# Altium schematic sheet MuxBoard.SchDoc(MuxBoard)
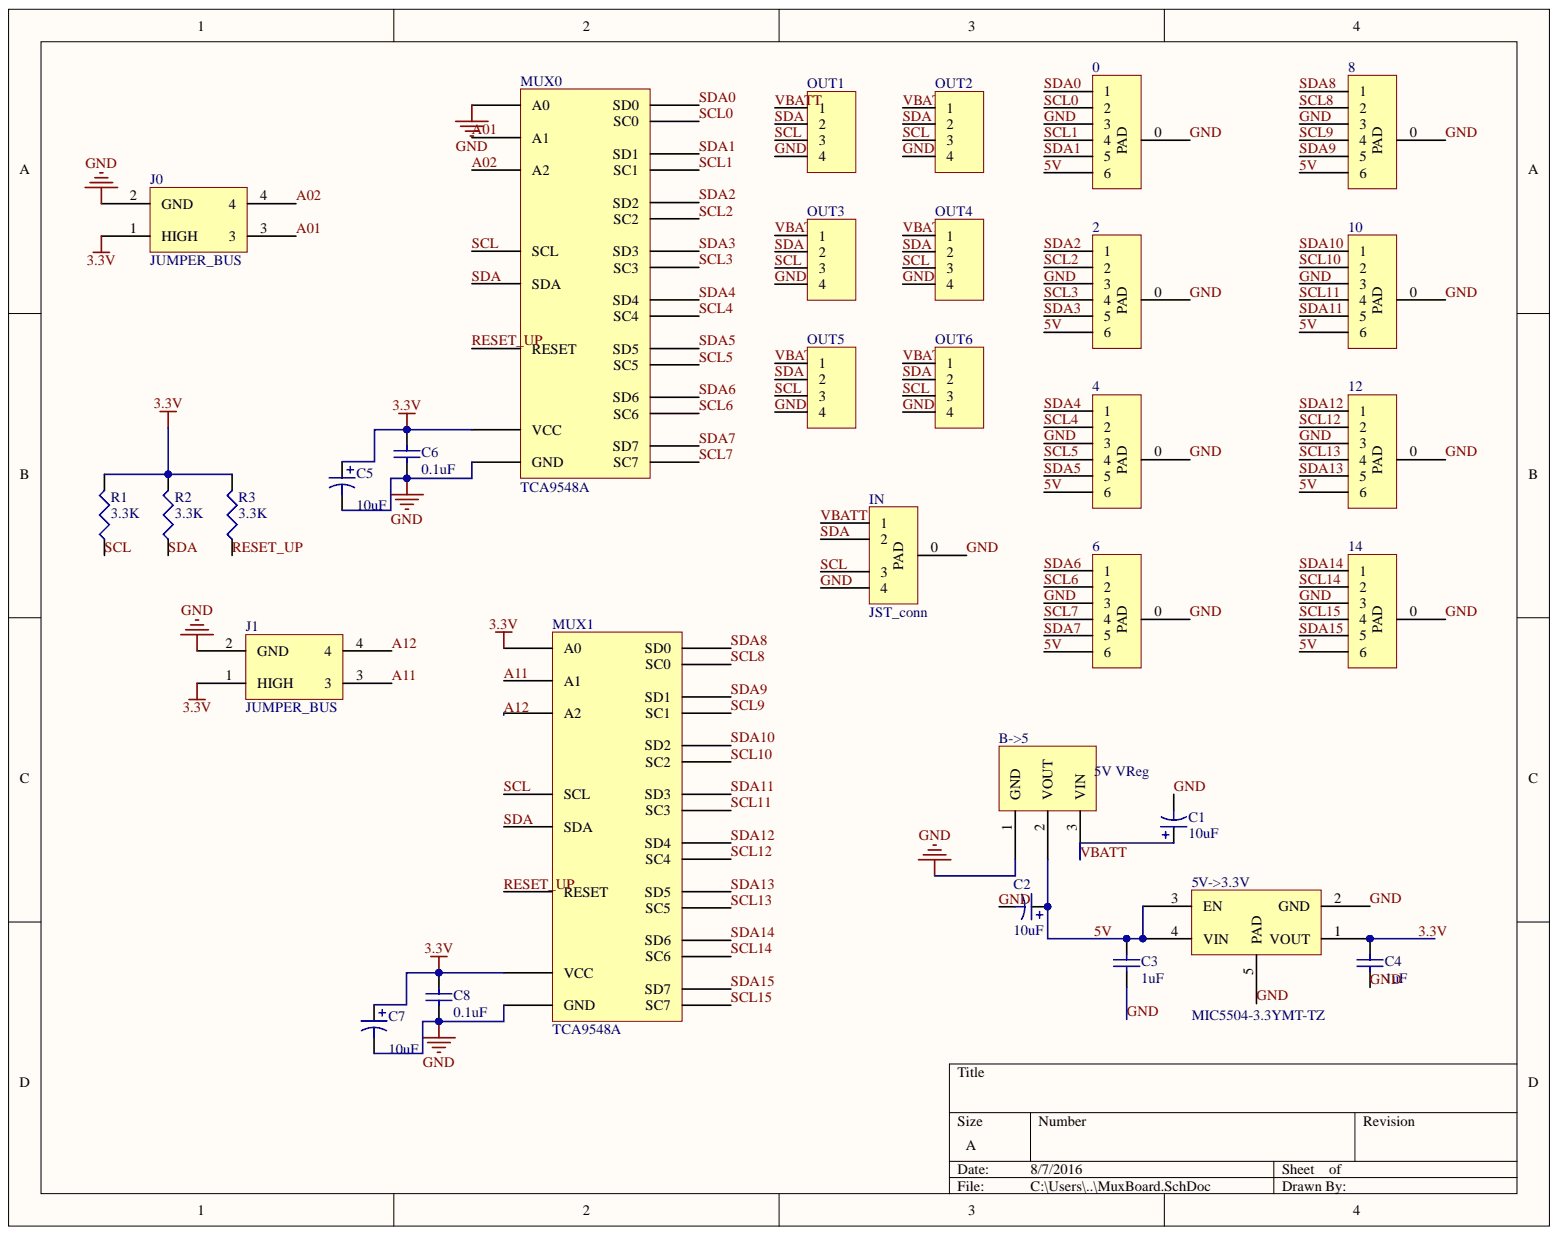
[diagram p0: MuxBoard.SchDoc]

## Parts
0  1  [6 Pin FFC/FPC Connector]
2  3  [6 Pin FFC/FPC Connector]
4  5  [6 Pin FFC/FPC Connector]
5V->3.3V  MIC5504-3.3YMT-TZ  [4-XDFN]
6  7  [6 Pin FFC/FPC Connector]  (value not drawn)
8  9  [6 Pin FFC/FPC Connector]  (value not drawn)
10  11  [6 Pin FFC/FPC Connector]  (value not drawn)
12  13  [6 Pin FFC/FPC Connector]  (value not drawn)
14  15  [6 Pin FFC/FPC Connector]  (value not drawn)
B->5  5V VReg  [SOT-223]
C1  value 10uF  [0805 Polarized]  (hidden comment: Cap Pol3)
C2  value 10uF  [0805 Polarized]  (hidden comment: Cap Pol3)
C3  value 1uF  [0402 Capacitor]  (hidden comment: Cap Semi)
C4  value 1uF  [0402 Capacitor]  (hidden comment: Cap Semi)
C5  value 10uF  [0805 Polarized]  (hidden comment: Cap Pol3)
C6  value 0.1uF  [1608[0603]]  (hidden comment: Cap Semi)
C7  value 10uF  [0805 Polarized]  (hidden comment: Cap Pol3)
C8  value 0.1uF  [1608[0603]]  (hidden comment: Cap Semi)
IN  JST_conn  [4 pin JST Connector]
J0  JUMPER_BUS  [JUMPER_BUS]
J1  JUMPER_BUS  [JUMPER_BUS]
MUX0  TCA9548A  [TTSOP-24]
MUX1  TCA9548A  [TTSOP-24]
OUT1  Header 4H  [myHDR_1x4H]  (value not drawn)
OUT2  Header 4H  [myHDR_1x4H]  (value not drawn)
OUT3  Header 4H  [myHDR_1x4H]  (value not drawn)
OUT4  Header 4H  [myHDR_1x4H]  (value not drawn)
OUT5  Header 4H  [myHDR_1x4H]  (value not drawn)
OUT6  Header 4H  [myHDR_1x4H]  (value not drawn)
R1  value 3.3K  [0402 Resistor]  (hidden comment: Res3)
R2  value 3.3K  [0402 Resistor]  (hidden comment: Res3)
R3  value 3.3K  [0402 Resistor]  (hidden comment: Res3)

## Nets
3.3V: 5V->3.3V.1(VOUT) C4.2 C5.1 C6.2 C7.1 C8.2 J0.1(HIGH) J1.1(HIGH) MUX0.24(VCC) MUX1.1(A0) MUX1.24(VCC) R1.2 R2.2 R3.2
5V: 0.6 2.6 4.6 5V->3.3V.3(EN) 5V->3.3V.4(VIN) 6.6 8.6 10.6 12.6 14.6 B->5.2 C2.1 C3.2
A01: J0.3 MUX0.2(A1)
A02: J0.4 MUX0.21(A2)
A11: J1.3 MUX1.2(A1)
A12: J1.4 MUX1.21(A2)
GND: 0.0 0.3 2.0 2.3 4.0 4.3 5V->3.3V.2(GND) 5V->3.3V.5(PAD) 6.0 6.3 8.0 8.3 10.0 10.3 12.0 12.3 14.0 14.3 B->5.1 C1.2 C2.2 C3.1 C4.1 C5.2 C6.1 C7.2 C8.1 IN.0 IN.4 J0.2(GND) J1.2(GND) MUX0.1(A0) MUX0.12(GND) MUX1.12(GND) OUT1.4 OUT2.4 OUT3.4 OUT4.4 OUT5.4 OUT6.4
RESET_UP: MUX0.3(RESET) MUX1.3(RESET) R3.1
SCL: IN.3 MUX0.22(SCL) MUX1.22(SCL) OUT1.3 OUT2.3 OUT3.3 OUT4.3 OUT5.3 OUT6.3 R1.1
SCL0: 0.2 MUX0.5(SC0)
SCL1: 0.4 MUX0.7(SC1)
SCL2: 2.2 MUX0.9(SC2)
SCL3: 2.4 MUX0.11(SC3)
SCL4: 4.2 MUX0.14(SC4)
SCL5: 4.4 MUX0.16(SC5)
SCL6: 6.2 MUX0.18(SC6)
SCL7: 6.4 MUX0.20(SC7)
SCL8: 8.2 MUX1.5(SC0)
SCL9: 8.4 MUX1.7(SC1)
SCL10: 10.2 MUX1.9(SC2)
SCL11: 10.4 MUX1.11(SC3)
SCL12: 12.2 MUX1.14(SC4)
SCL13: 12.4 MUX1.16(SC5)
SCL14: 14.2 MUX1.18(SC6)
SCL15: 14.4 MUX1.20(SC7)
SDA: IN.2 MUX0.23(SDA) MUX1.23(SDA) OUT1.2 OUT2.2 OUT3.2 OUT4.2 OUT5.2 OUT6.2 R2.1
SDA0: 0.1 MUX0.4(SD0)
SDA1: 0.5 MUX0.6(SD1)
SDA2: 2.1 MUX0.8(SD2)
SDA3: 2.5 MUX0.10(SD3)
SDA4: 4.1 MUX0.13(SD4)
SDA5: 4.5 MUX0.15(SD5)
SDA6: 6.1 MUX0.17(SD6)
SDA7: 6.5 MUX0.19(SD7)
SDA8: 8.1 MUX1.4(SD0)
SDA9: 8.5 MUX1.6(SD1)
SDA10: 10.1 MUX1.8(SD2)
SDA11: 10.5 MUX1.10(SD3)
SDA12: 12.1 MUX1.13(SD4)
SDA13: 12.5 MUX1.15(SD5)
SDA14: 14.1 MUX1.17(SD6)
SDA15: 14.5 MUX1.19(SD7)
VBATT: B->5.3 C1.1 IN.1 OUT1.1 OUT2.1 OUT3.1 OUT4.1 OUT5.1 OUT6.1

## Library data of the parts
0 2 4 6 8 10 12 14 = Component_1: Component Kind: Standard; Digikey Part #: WM12362CT-ND; Digikey Part # (Cable): WM11444-ND; Library Name: SensorFingerComponents.SchLib
C1 C2 C5 C7 = Cap Pol3: Polarized Capacitor (Surface Mount); Component Kind: Standard; Digikey Part #: 478-8418-1-ND; LatestRevisionDate: 29-May-2009; LatestRevisionNote: IPC-7351 Footprint Added.; Library Name: Miscellaneous Devices.IntLib; PackageDescription: Chip Capacitor; PackageReference: C0805; Published: 8-Jun-2000; Signal Integrity: Cap Pol; Simulation: CAP; Publisher: Altium Limited
C3 C4 = Cap Semi: Capacitor (Semiconductor SIM Model); Max Voltage: 10V; Component Kind: Standard; Digikey Part #: 490-3890-1-ND; LatestRevisionDate: 29-May-2009; LatestRevisionNote: IPC-7351 Footprint Added.; Library Name: Miscellaneous Devices.IntLib; PackageDescription: Chip Capacitor; PackageReference: 0402; Published: 8-Jun-2000; Signal Integrity: Cap Semi; Simulation: CAP; Publisher: Altium Limited
C6 C8 = Cap Semi: Capacitor (Semiconductor SIM Model); Component Kind: Standard; LatestRevisionDate: 29-May-2009; LatestRevisionNote: IPC-7351 Footprint Added.; Library Name: Miscellaneous Devices.IntLib; PackageDescription: Chip Capacitor; PackageReference: C1206; Published: 8-Jun-2000; Signal Integrity: Cap Semi; Simulation: CAP; Publisher: Altium Limited
MUX0 MUX1 = TCA9548A: 8-Channel I2C Switch; Manufacturer: Texas Instruments; Component Kind: Standard; Digikey Part #: 296-34905-1-ND; Part Number: TCA9548A; Library Name: SensorFingerComponents.SchLib
OUT1-OUT6 = Header 4H: Header, 4-Pin, Right Angle; Component Kind: Standard; Digikey Model #: 455-2645-1-ND; LatestRevisionDate: 17-Jul-2002; LatestRevisionNote: Re-released for DXP Platform.; Library Name: Miscellaneous Connectors.IntLib; Signal Integrity: Connector; Publisher: Altium Limited
R1-R3 = Res3: Resistor; Component Kind: Standard; LatestRevisionDate: 29-May-2009; LatestRevisionNote: IPC-7351 Footprint Added.; Library Name: Miscellaneous Devices.IntLib; PackageDescription: Chip Resistor; PackageReference: J1-0603; Published: 8-Jun-2000; Signal Integrity: Res; Simulation: RESISTOR; Publisher: Altium Limited
5V->3.3V = MIC5504-3.3YMT-TZ: Component Kind: Standard; Library Name: SensorFingerComponents.SchLib
B->5 = 5V VReg: Component Kind: Standard; Digikey Part #: NCP1117ST50T3GOSCT-ND; Library Name: SensorFingerComponents.SchLib
IN = JST_conn: Component Kind: Standard; Digikey Part #: 455-2645-1-ND; Digikey Part # (Crimp Ends): 455-1325-1-ND; Digikey Part # (Male Conn): 455-1488-ND; Library Name: SensorFingerComponents.SchLib
J0 J1 = JUMPER_BUS: Component Kind: Standard; Library Name: SensorFingerComponents.SchLib
